# Altium schematic sheet Connectors.SchDoc
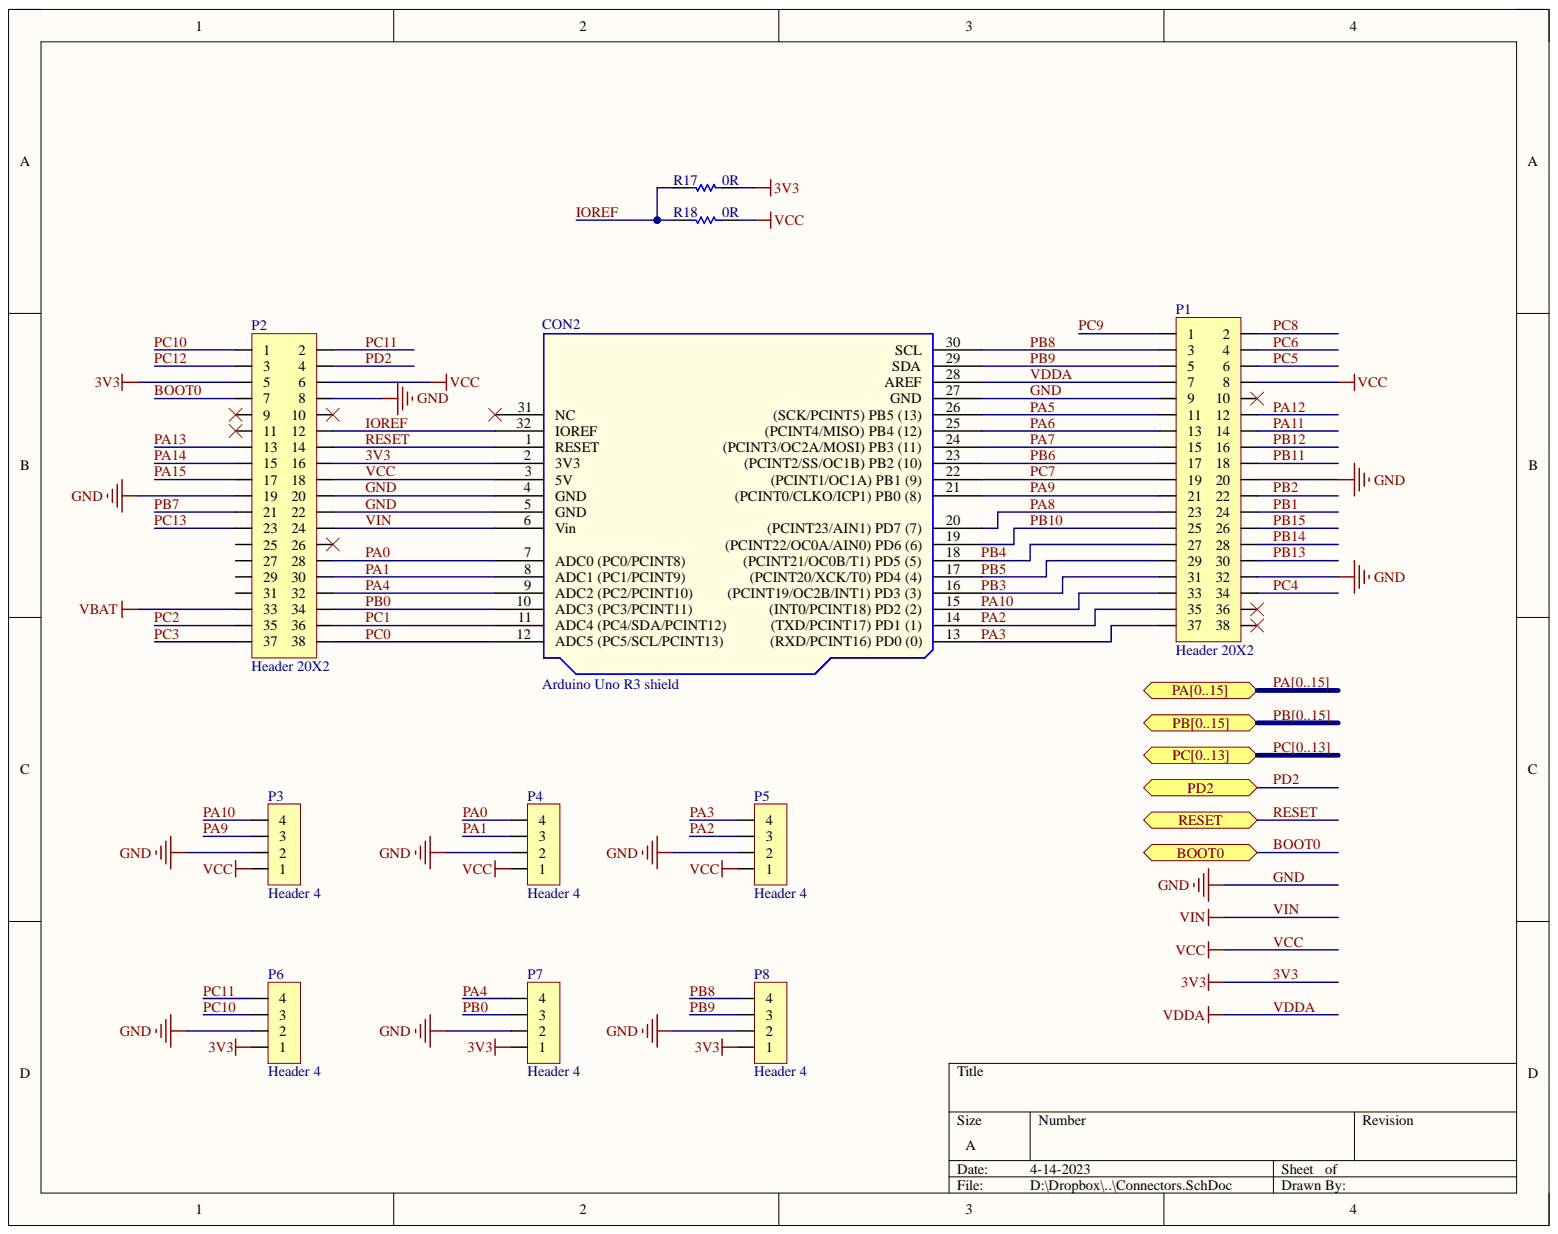
[diagram p0: Connectors.SchDoc]

## Parts
CON2  Arduino Uno R3 shield  [Arduino Uno R3 Shield]
P1  Header 20X2  [Header 19x2]
P2  Header 20X2  [Header 19x2]
P3  Header 4  [CON Header 4 - RED]
P4  Header 4  [CON Header 4 - RED]
P5  Header 4  [CON Header 4 - RED]
P6  Header 4  [CON Header 4]
P7  Header 4  [CON Header 4]
P8  Header 4  [CON Header 4]
R17  0R  [R 0603 (1608)]
R18  0R  [R 0603 (1608)]

## Ports
BOOT0, PA0, PA1, PA2, PA3, PA4, PA5, PA6, PA7, PA8, PA9, PA10, PA11, PA12, PA13, PA14, PA15, PA[0..15], PB0, PB1, PB2, PB3, PB4, PB5, PB6, PB7, PB8, PB9, PB10, PB11, PB12, PB13, PB14, PB15, PB[0..15], PC0, PC1, PC2, PC3, PC4, PC5, PC6, PC7, PC8, PC9, PC10, PC11, PC12, PC13, PC[0..13], PD2, RESET

## Nets
VBAT: P2.33
3V3: CON2.2(3V3) P2.5 P2.16 P6.1 P7.1 P8.1 R17.2
BOOT0: P2.7 ; port BOOT0
GND: CON2.4(GND) CON2.5(GND) CON2.27(GND) P1.9 P1.20 P1.32 P2.8 P2.19 P2.20 P2.22 P3.2 P4.2 P5.2 P6.2 P7.2 P8.2
IOREF: CON2.32(IOREF) P2.12 R17.1 R18.1
PA0: CON2.7(ADC0_(PC0/PCINT8)) P2.28 P4.4 ; port PA[0..15]  [also labelled PA]
PA1: CON2.8(ADC1_(PC1/PCINT9)) P2.30 P4.3 ; port PA[0..15]  [also labelled PA]
PA2: CON2.14((TXD/PCINT17)_PD1_(1)) P1.35 P5.3 ; port PA[0..15]  [also labelled PA]
PA3: CON2.13((RXD/PCINT16)_PD0_(0)) P1.37 P5.4 ; port PA[0..15]  [also labelled PA]
PA4: CON2.9(ADC2_(PC2/PCINT10)) P2.32 P7.4 ; port PA[0..15]  [also labelled PA]
PA5: CON2.26((SCK/PCINT5)_PB5_(13)) P1.11 ; port PA[0..15]  [also labelled PA]
PA6: CON2.25((PCINT4/MISO)_PB4_(12)) P1.13 ; port PA[0..15]  [also labelled PA]
PA7: CON2.24((PCINT3/OC2A/MOSI)_PB3_(11)) P1.15 ; port PA[0..15]  [also labelled PA]
PA8: CON2.20((PCINT23/AIN1)_PD7_(7)) P1.23 ; port PA[0..15]  [also labelled PA]
PA9: CON2.21((PCINT0/CLKO/ICP1)_PB0_(8)) P1.21 P3.3 ; port PA[0..15]  [also labelled PA]
PA10: CON2.15((INT0/PCINT18)_PD2_(2)) P1.33 P3.4 ; port PA[0..15]  [also labelled PA]
PA11: P1.14 ; port PA[0..15]  [also labelled PA]
PA12: P1.12 ; port PA[0..15]  [also labelled PA]
PA13: P2.13 ; port PA[0..15]  [also labelled PA]
PA14: P2.15 ; port PA[0..15]  [also labelled PA]
PA15: P2.17 ; port PA[0..15]  [also labelled PA]
PB0: CON2.10(ADC3_(PC3/PCINT11)) P2.34 P7.3 ; port PB[0..15]  [also labelled PB]
PB1: P1.24 ; port PB[0..15]  [also labelled PB]
PB2: P1.22 ; port PB[0..15]  [also labelled PB]
PB3: CON2.16((PCINT19/OC2B/INT1)_PD3_(3)) P1.31 ; port PB[0..15]  [also labelled PB]
PB4: CON2.18((PCINT21/OC0B/T1)_PD5_(5)) P1.27 ; port PB[0..15]  [also labelled PB]
PB5: CON2.17((PCINT20/XCK/T0)_PD4_(4)) P1.29 ; port PB[0..15]  [also labelled PB]
PB6: CON2.23((PCINT2/SS/OC1B)_PB2_(10)) P1.17 ; port PB[0..15]  [also labelled PB]
PB7: P2.21 ; port PB[0..15]  [also labelled PB]
PB8: CON2.30(SCL) P1.3 P8.4 ; port PB[0..15]  [also labelled PB]
PB9: CON2.29(SDA) P1.5 P8.3 ; port PB[0..15]  [also labelled PB]
PB10: CON2.19((PCINT22/OC0A/AIN0)_PD6_(6)) P1.25 ; port PB[0..15]  [also labelled PB]
PB11: P1.18 ; port PB[0..15]  [also labelled PB]
PB12: P1.16 ; port PB[0..15]  [also labelled PB]
PB13: P1.30 ; port PB[0..15]  [also labelled PB]
PB14: P1.28 ; port PB[0..15]  [also labelled PB]
PB15: P1.26 ; port PB[0..15]  [also labelled PB]
PC0: CON2.12(ADC5_(PC5/SCL/PCINT13)) P2.38 ; port PC[0..13]  [also labelled PC]
PC1: CON2.11(ADC4_(PC4/SDA/PCINT12)) P2.36 ; port PC[0..13]  [also labelled PC]
PC2: P2.35 ; port PC[0..13]  [also labelled PC]
PC3: P2.37 ; port PC[0..13]  [also labelled PC]
PC4: P1.34 ; port PC[0..13]  [also labelled PC]
PC5: P1.6 ; port PC[0..13]  [also labelled PC]
PC6: P1.4 ; port PC[0..13]  [also labelled PC]
PC7: CON2.22((PCINT1/OC1A)_PB1_(9)) P1.19 ; port PC[0..13]  [also labelled PC]
PC8: P1.2 ; port PC[0..13]  [also labelled PC]
PC9: P1.1 ; port PC[0..13]  [also labelled PC]
PC10: P2.1 P6.3 ; port PC[0..13]  [also labelled PC]
PC11: P2.2 P6.4 ; port PC[0..13]  [also labelled PC]
PC12: P2.3 ; port PC[0..13]  [also labelled PC]
PC13: P2.23 ; port PC[0..13]  [also labelled PC]
PD2: P2.4 ; port PD2
RESET: CON2.1(RESET) P2.14 ; port RESET
VCC: CON2.3(5V) P1.8 P2.6 P2.18 P3.1 P4.1 P5.1 R18.2
VDDA: CON2.28(AREF) P1.7
VIN: CON2.6(Vin) P2.24

Not connected: CON2 31(NC); P1 10 36 38; P2 9 10 11 25 26 27 29 31

## Library data of the parts
CON2 = Arduino Uno R3 shield: Arduino Uno R3 shield pins connection; Component Kind: Standard; Library Name: BKIT4U_LIB.SchLib
P1 P2 = Header 19X2: Header, 38-Pin, Dual row; Component Kind: Standard; LatestRevisionDate: 17-Jul-2002; LatestRevisionNote: Re-released for DXP Platform.; Library Name: BKIT4U_LIB.SchLib; Publisher: Altium Limited
P3-P8 = Header 4: Header, 4-Pin; Component Kind: Standard; Library Name: BKIT4U_LIB.SchLib
R17 R18 = Resistor: Component Kind: Standard; Description: Resistor; LatestRevisionDate: 17-Jul-2002; LatestRevisionNote: Re-released for DXP Platform.; Library Name: BKIT4U_LIB.SchLib; PackageReference: AXIAL-0.3; Published: 8-Jun-2000; Publisher: Altium Limited
